# Altium schematic sheet PowerStage.SchDoc
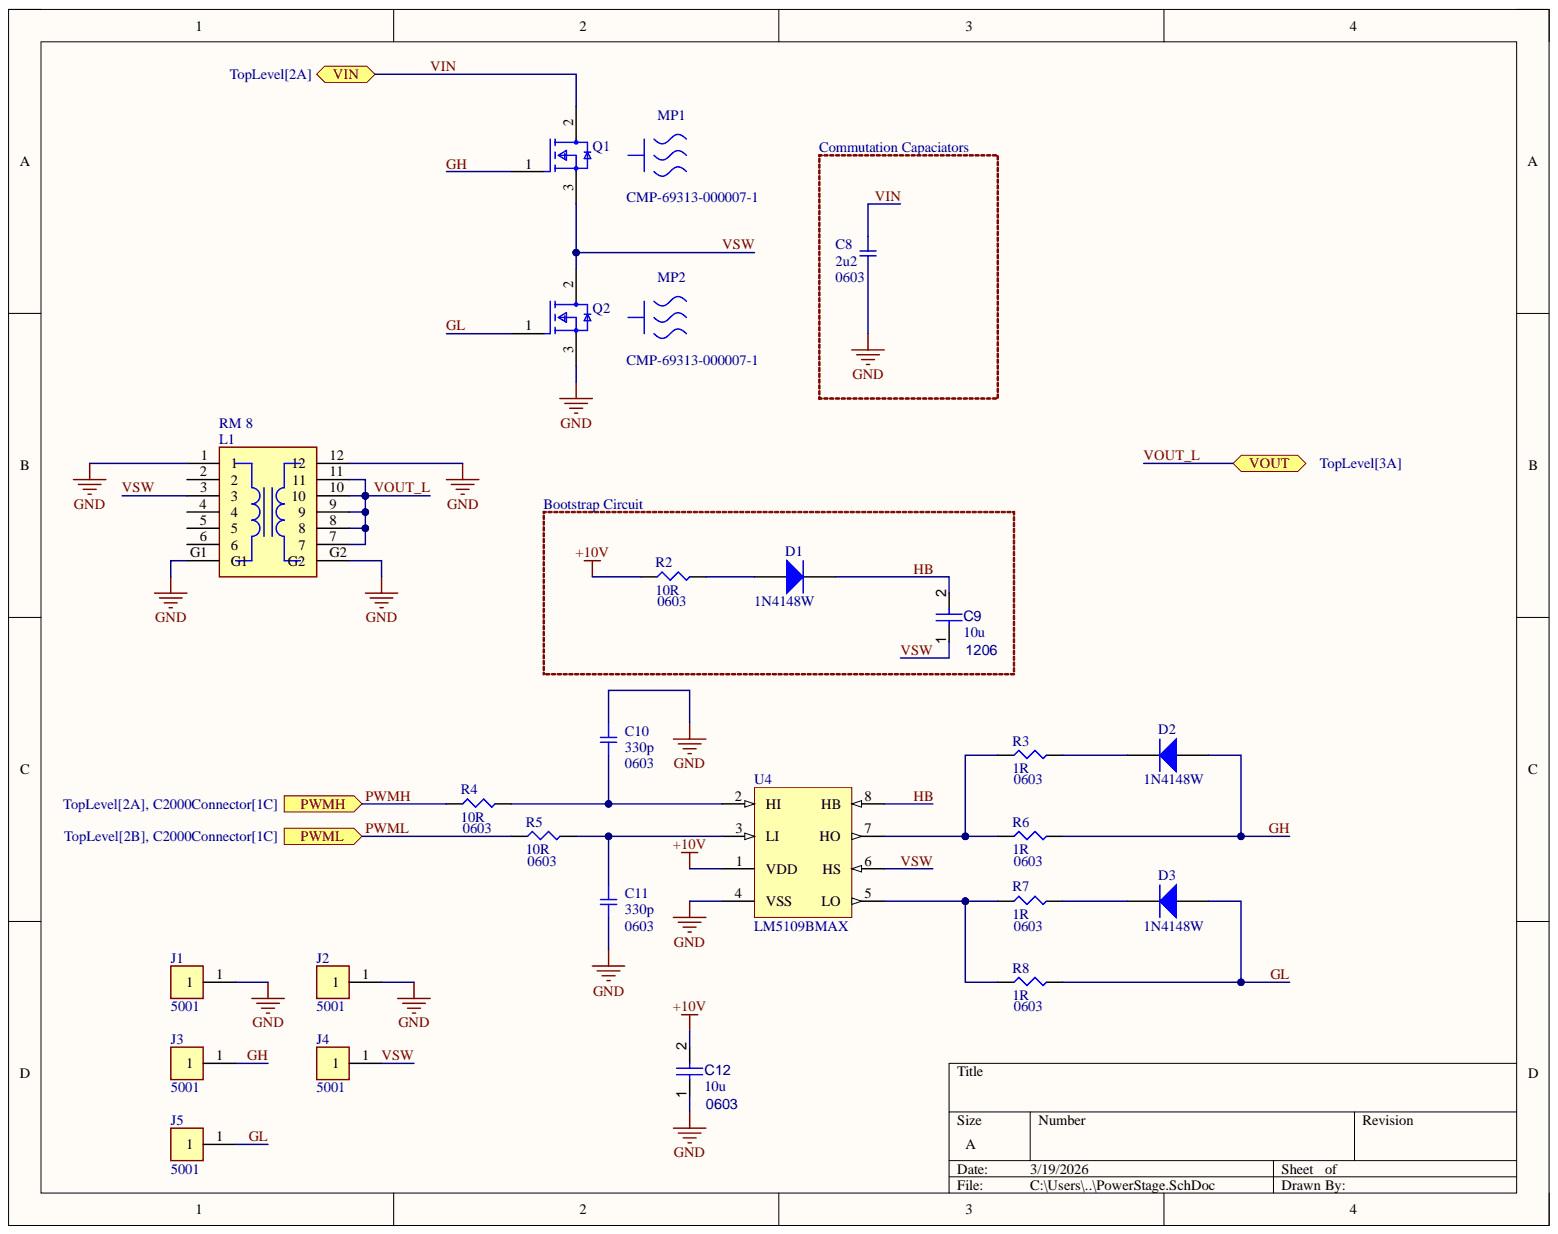
[diagram p0: PowerStage.SchDoc]

## Parts
C8  0603  value 2u2  [SMD-0603C]
C9  1206  value 10u  [CAPC3216X180X50NL20T25]
C10  0603  value 330p  [SMD-0603C]
C11  0603  value 330p  [SMD-0603C]
C12  0603  value 10u  [CAPC0603(1608)100_L]
D1  1N4148W  [DIOD-SOD123-2_V]
D2  1N4148W  [DIOD-SOD123-2_V]
D3  1N4148W  [DIOD-SOD123-2_V]
J1  5001  [FP-5001-MFG]
J2  5001  [FP-5001-MFG]
J3  5001  [FP-5001-MFG]
J4  5001  [FP-5001-MFG]
J5  5001  [FP-5001-MFG]
L1  B65812C1512T001  [B65812C1512T001]  (value not drawn)
MP1  CMP-69313-000007-1  [FP-507302B00000G-MFG]
MP2  CMP-69313-000007-1  [FP-507302B00000G-MFG]
Q1  CMP-02550-000190-1  [FP-X15-0364-MFG]  (value not drawn)
Q2  CMP-02550-000190-1  [FP-X15-0364-MFG]  (value not drawn)
R2  0603  value 10R  [SMD-0603-RES]
R3  0603  value 1R  [SMD-0603-RES]
R4  0603  value 10R  [SMD-0603-RES]
R5  0603  value 10R  [SMD-0603-RES]
R6  0603  value 1R  [SMD-0603-RES]
R7  0603  value 1R  [SMD-0603-RES]
R8  0603  value 1R  [SMD-0603-RES]
U4  LM5109BMAX  [M08A_L]

## Ports
PWMH, PWML, VIN, VOUT

## Nets
+10V: C12.2 R2.1 U4.1(VDD)
GND: C8.2 C10.1 C11.2 C12.1 J1.1 J2.1 L1.1 L1.12 L1.G1 L1.G2 Q2.3 U4.4(VSS)
GH: D2.1 J3.1 Q1.1 R6.2
GL: D3.1 J5.1 Q2.1 R8.2
HB: C9.2 D1.2 U4.8(HB)
PWMH: R4.1 ; port PWMH
PWML: R5.1 ; port PWML
VIN: C8.1 Q1.2 ; port VIN
VOUT_L: L1.7 L1.8 L1.9 L1.10 L1.11 ; port VOUT
VSW: C9.1 J4.1 L1.3 Q1.3 Q2.2 U4.6(HS)
NetC10_2: C10.2 R4.2 U4.2(HI)
NetC11_1: C11.1 R5.2 U4.3(LI)
NetD1_1: D1.1 R2.2
NetD2_2: D2.2 R3.2
NetD3_2: D3.2 R7.2
NetL1_2: L1.2
NetL1_4: L1.4
NetL1_5: L1.5
NetL1_6: L1.6
NetR3_1: R3.1 R6.1 U4.7(HO)
NetR7_1: R7.1 R8.1 U4.5(LO)

## Library data of the parts
C8 = CMP-003-000010-1: CAP CER 2.2UF 16V X5R 0603; Manufacturer: Murata Electronics North America; Part Number: GRM188R61C225KE15D; @ qty: 0; Minimum Quantity: 1; Tolerance: ±10%; Unit Price (USD): 0.12; Applications: General Purpose; Component Kind: Standard; Component Type: Standard; Datasheets: http://www.murata.com/~/media/webrenewal/support/library/catalog/products/capacitor/mlcc/c02e.pdf; Design Item ID: GRM188R61C225KE15D; Digi-Key Part Number: 490-3296-1-ND; Factory Stock: 0; Features: -; Height - Seated (Max): -; Image: //media.digikey.com/Renders/Murata%20Renders/ZRB-SERIES-0402.jpg; Lead Spacing: -; Lead Style: -; Mounting Type: Surface Mount, MLCC; Operating Temperature: -55°C ~ 85°C; Package / Case: 0603 (1608 Metric); Packaging: Cut Tape (CT); Part Status: Active; Pin Count: 2; Quantity Available: 1668676; Ratings: -; Series: GRM; Size / Dimension: 0.063" L x 0.031" W (1.60mm x 0.80mm); Source: PV Buck v2.SCHLIB; Temperature Coefficient: X5R; Thickness (Max): 0.035" (0.90mm); Voltage: 16V; Library Name: Ishaan Gupta
C9 = CMP-001-00016-6: Chip Capacitor, 10 uF, +/- 10%, 16 V, 1206 (3216 Metric); Capacitor Type: Ceramic; Voltage Rating: 16V; Case/Package: 1206; Component Kind: Standard; Max Operating Temperature: 125°C; Min Operating Temperature: -55°C; Mounting Technology: SM; Pins: 2; RoHS Compliant: True; Tolerance: 10%; Library Name: Ishaan Gupta
C10 C11 = CMP-003-000007-1: CAP CER 330PF 50V NP0 0603; Manufacturer: Murata Electronics North America; Part Number: GRM1885C1H331GA01D; @ qty: 0; Minimum Quantity: 1; Temperature Coefficient: C0G, NP0; Tolerance: ±2%; Unit Price (USD): 0.16; Applications: General Purpose; Component Kind: Standard; Component Type: Standard; Datasheets: http://www.murata.com/~/media/webrenewal/support/library/catalog/products/capacitor/mlcc/c02e.pdf; Design Item ID: GRM1885C1H331GA01D; Digi-Key Part Number: 490-11460-1-ND; Factory Stock: 0; Features: -; Height - Seated (Max): -; Image: //media.digikey.com/Renders/Murata%20Renders/ZRB-SERIES-0402.jpg; Lead Spacing: -; Lead Style: -; Mounting Type: Surface Mount, MLCC; Operating Temperature: -55°C ~ 125°C; Package / Case: 0603 (1608 Metric); Packaging: Cut Tape (CT); Part Status: Active; Pin Count: 2; Quantity Available: 7231; Ratings: -; Series: GRM; Size / Dimension: 0.063" L x 0.031" W (1.60mm x 0.80mm); Source: PV Buck v2.SCHLIB; Thickness (Max): 0.035" (0.90mm); Voltage: 50V; Library Name: Ishaan Gupta
C12 = CMP-001-00075-4: Chip Capacitor, 10µF +/-20%, 10V, 0603, Thickness 1 mm; Tolerance: 10%; Case/Package: 0603; Component Kind: Standard; Max Operating Temperature: 85°C; Min Operating Temperature: -55°C; Mounting Technology: SMT; Pins: 2; Voltage Rating: 10V; Library Name: Ishaan Gupta
D1-D3 = CMP-005-000001-1: Fast Switching Diode, 100 V, 0.15 A, -65 to 150 degC, 2-Pin?SOD123, RoHS, Tape and Reel; Manufacturer: Diodes; Manufacturer Part Number: 1N4148W-7-F; Brand: DiodesIncorporated; Configuration: Single; If - Forward Current: 0.15A; Ir - Reverse Current: 1uA; Max Surge Current: 2A; Peak Reverse Voltage: 100V; Recovery Time: 4ns; Unit Weight: 0.000353oz; Vf - Forward Voltage: 1.25V; Component Kind: Standard; Component Type: Standard; Datasheet URL: http://www.farnell.com/datasheets/1915529.pdf; Datasheet Version: Rev. 24-2, 10/2013; Design Item ID: CMP-2000-04932-2; Factory Pack Quantity: 3000; Height: 1.25mm; Length: 2.85mm; Manufacturer URL: http://www.diodes.com/; Maximum Operating Temperature: +150C; Minimum Operating Temperature: -65C; Mounting Style: SMD/SMT; Operating Temperature Range: -65Cto+150C; Package / Case: SOD-123; Package Reference: SOD123-2; Package URL: http://www.diodes.com/datasheets/ds18004.pdf; Packaging: Reel; Pin Count: 2; Product: SwitchingDiodes; Product Category: Diodes-GeneralPurpose,Power,Switching; RoHS: True; Series: 1N4148; Source: PV Buck v2.SCHLIB; Type: SwitchingDiode; Width: 1.7mm; Library Name: Ishaan Gupta
J1-J5 = CMP-010-000010-1: PC TEST POINT MINIATURE BLACK; Manufacturer: Keystone Electronics; Body Material: Bronze; Hole Diameter: 1.6mm; Inside Diameter: 1.27mm; Insulation: Insulated; Number of Contacts: 1; Outside Diameter: 2.54mm; Plating: Silver; Color: Black; Component Kind: Standard; Component Type: Standard; Contact Material: Bronze; Contact Plating: Silver; Design Item ID: CMP-2000-05181-2; Diameter: 2.54mm; Flammability Rating: UL94 V-0; Height: 0.3inch; Housing Color: Black; Insulation Material: Nylon; Lead Free: Lead Free; Length: 7.62mm; Manufacturer Part Number: 5001; Material: Nylon; Mount: Through Hole; Number of Ports: 1; Orientation: Straight; Package Quantity: 100; Packaging: Bulk; Pin Count: 1; REACH SVHC: No SVHC; RoHS Compliant: Yes; Series: THM; Source: PV Buck v2.SCHLIB; Termination: Through Hole; Library Name: Ishaan Gupta
L1 = CMP-006-000005-1: BOBBIN COIL FORMER RM 8; Component Kind: Standard; Component Type: Standard; Design Item ID: B65812C1512T001; Pin Count: 14; Source: PV Buck v2.SCHLIB; Library Name: Ishaan Gupta
MP1 MP2 = CMP-010-000011-1: HEATSINK TO-220 2.5W LOW PROFILE; Manufacturer: Aavid Thermalloy; Manufacturer Part Number: 507302B00000G; Finish: Anodized; Natural Thermal Resistance: 24°C/W; Shape: Square; Thermal Resistance @ Forced Air Flow (100 LFM): 10°C/W; Case/Package: TO-220; Color: Black; Component Kind: Standard; Component Type: Standard; Depth: 19.05mm; Design Item ID: CMP-69313-000007-1; Height: 9.65mm; Height Off Base: 0.38inch; Lead Free: Lead Free; Length: 19.05mm; Material: Aluminium; Mount: Bolt; Package Cooled: TO-220; Package Quantity: 2000; Packaging: Bulk; Pin Count: 0; Radiation Hardening: No; REACH SVHC: No SVHC; RoHS Compliant: Yes; Series: 5073; Source: PV Buck v2.SCHLIB; Type: Passive; Weight: 0.25lb; Width: 19.05mm; Library Name: Ishaan Gupta
Q1 Q2 = CMP-010-000008-1: MOSFET N-CH 100V 14A TO-220AB; Manufacturer: Vishay; Manufacturer Part Number: IRF530PBF; Depletion Mode: False; Drain To Source Resistance: 0.16O; Gate Charge At Vgs: 26nC @ 10V; Gate To Source Voltage: 20V; Input Capacitace At Vds: 670pF @ 25V; Max Forward Diode Voltage: 2.5V; Max Junction Temp: +175°C; Number Of Channels: 1; PackagerOD: TO-220; Power Dissipation: 88W; Pulse Drain Current: 56A; Reverse Recovery Charge: 850nC; Reverse Recovery Time: 150ns; Rthja Max: 62°C/W; Standoff Height: 3.33mm; Threshold Vgs Max: 4V; Threshold Vgs Min: 2V; Turn Off Delay Time: 23ns; Turn On Delay Time: 10ns; Automotive: No; Category: Trans; Component Kind: Standard; Component Type: Standard; ComponentLink1Description: Datasheet; ComponentLink1URL: http://www.vishay.com/docs/91019/91019.pdf; Continuous Drain Current: 14A; Design Item ID: CMP-02550-000190-1; Device Class L1: Discrete Semiconductors; Device Class L2: Transistors; Device Class L3: MOSFETs; Digikey Description: MOSFET N-CH 100V 14A TO-220AB; Drain To Source Breakdown Voltage: 100V; Drain To Source Voltage: 100V; Height: 19.89mm; Lead Free: Yes; Mouser Description: MOSFET N-Chan 100V 14 Amp; Number Of N Channels: 1; Octopart: https://octopart.com/irf530pbf-vishay-46547999; Package: X15-0364; Pin Count: 3; RoHS: TRUE; Source: PV Buck v2.SCHLIB; Temperature: -55°C to +175°C; Temperature Range High: +175°C; Temperature Range Low: -55°C; Library Name: Ishaan Gupta
R2 R4 R5 = CMP-009-000014-1: RES SMD 10 OHM 1% 1/10W 0603; Manufacturer: Panasonic Electronic Components; Part Number: ERJ-3EKF10R0V; @ qty: 1500; Factory Stock: 0; Minimum Quantity: 1; Power (Watts): 0.1W, 1/10W; Temperature Coefficient: ±100ppm/°C; Unit Price (USD): 0.00379; Component Kind: Standard; Component Type: Standard; Composition: Thick Film; Datasheets: http://industrial.panasonic.com/www-cgi/jvcr13pz.cgi?E+PZ+3+AOA0002+ERJ3EKF10R0V+7+WW; Design Item ID: ERJ-3EKF10R0V; Digi-Key Part Number: P10.0HCT-ND; Failure Rate: -; Features: Automotive AEC-Q200; Height - Seated (Max): 0.022" (0.55mm); Image: //media.digikey.com/Photos/Panasonic%20Photos/MFG_ERJ-P6W%20Series.jpg; Number of Terminations: 2; Operating Temperature: -55°C ~ 155°C; Package / Case: 0603 (1608 Metric); Packaging: Cut Tape (CT); Part Status: Active; Pin Count: 2; Quantity Available: 676435; Series: ERJ; Size / Dimension: 0.063" L x 0.031" W (1.60mm x 0.80mm); Source: PV Buck v2.SCHLIB; Supplier Device Package: 0603; Tolerance: ±1%; Library Name: Ishaan Gupta
R3 R6-R8 = CMP-009-000012-1: RES SMD 1 OHM 1% 1/4W 0603; Manufacturer: Panasonic Electronic Components; Part Number: ERJ-3BQF1R0V; @ qty: 1500; Factory Stock: 0; Minimum Quantity: 1; Power (Watts): 0.25W, 1/4W; Temperature Coefficient: ±200ppm/°C; Unit Price (USD): 0.05386; Component Kind: Standard; Component Type: Standard; Composition: Thick Film; Datasheets: https://industrial.panasonic.com/cdbs/www-data/pdf/RDA0000/AOA0000C239.pdf; Design Item ID: ERJ-3BQF1R0V; Digi-Key Part Number: P17371CT-ND; Failure Rate: -; Features: Automotive AEC-Q200, Current Sense; Height - Seated (Max): 0.022" (0.55mm); Image: //media.digikey.com/Photos/Panasonic%20Photos/MFG_ERJ-P6W%20Series.jpg; Number of Terminations: 2; Operating Temperature: -55°C ~ 125°C; Package / Case: 0603 (1608 Metric); Packaging: Cut Tape (CT); Part Status: Active; Pin Count: 2; Quantity Available: 30514; Series: ERJ; Size / Dimension: 0.063" L x 0.031" W (1.60mm x 0.80mm); Source: PV Buck v2.SCHLIB; Supplier Device Package: 0603; Tolerance: ±1%; Library Name: Ishaan Gupta
U4 = CMP-010-000006-1: High Voltage 1A Peak Half Bridge Gate Driver, 8-pin Narrow SOIC; Manufacturer: TI National Semiconductor; CompType: MiscPower; PackageTopMarking: NSZXTT*L5109*BMA; PowerWise: N; Code_IPC: SOIC127P600-8; Code_JEDEC: MS-012-AA; Component Kind: Standard; Component Type: Standard; ComponentLink1Description: LM5109B Datasheet; ComponentLink1URL: http://www.national.com/ds/LM/LM5109B.pdf; ComponentLink2Description: LM5109B Web Page; ComponentLink2URL: http://www.national.com/pf/LM/LM5109B.html; ComponentLink3Description: M08A Package Page; ComponentLink3URL: http://www.national.com/packaging/folders/m08a.html; DatasheetVersion: Feb-2007; Design Item ID: CMP-0063-00512-2; Manufacturer Part Number: LM5109BMAX; PackageDescription: SOIC Narrow, 4.9 x 3.9 x 1.45mm, 8 Lead, 1.27mm Pitch; PackageReference: M08A; PackageVersion: revM, May-2009; Pin Count: 8; PinCount: 8; RevisionNote: Component URLs added; RoHS: N; Source: PV Buck v2.SCHLIB; Library Name: Ishaan Gupta
